# Altium schematic sheet 51电子秤.SchDoc
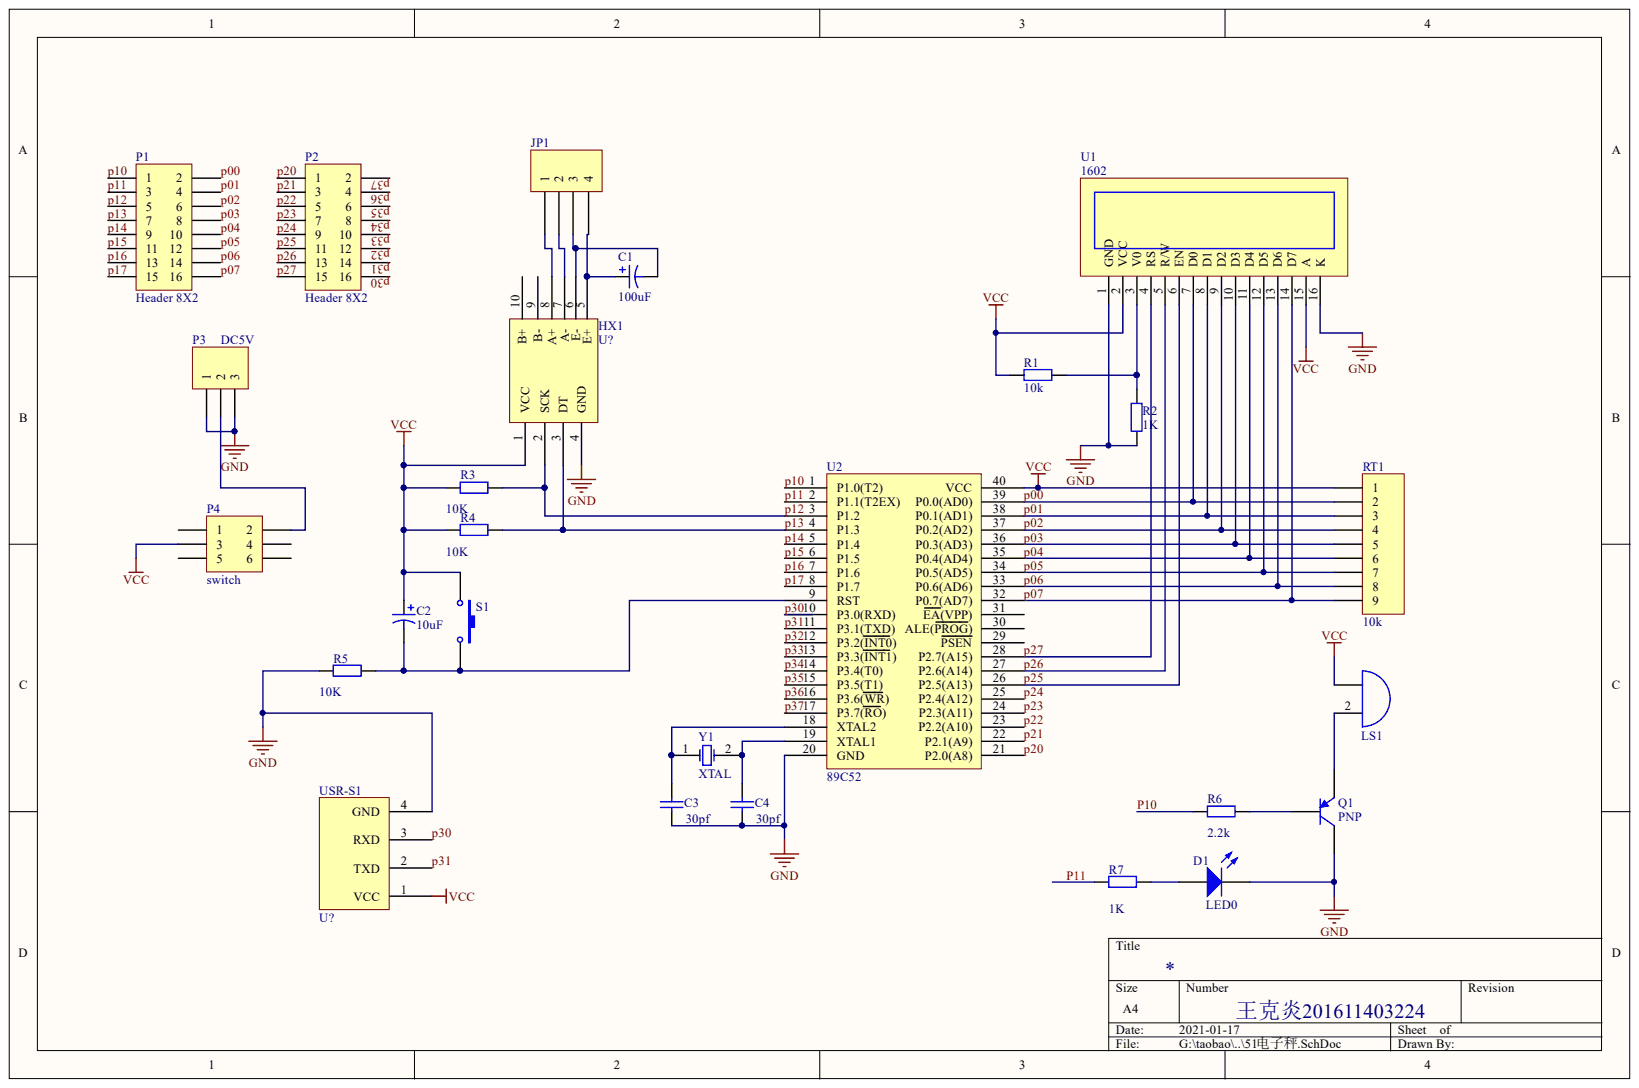
[diagram p0: 51电子秤.SchDoc]

## Parts
C1  value 100uF  (hidden comment: Cap Pol2)
C2  value 10uF  (hidden comment: Cap Pol2)
C3  value 30pf  (hidden comment: Cap)
C4  value 30pf  (hidden comment: Cap)
D1  LED0
HX1  U?
JP1  JP?
LS1  Bell  (value not drawn)
P1  Header 8X2
P2  Header 8X2
P3  DC5V
P4  switch
Q1  PNP
R1  value 10k  (hidden comment: Res2)
R2  value 1K  (hidden comment: Res2)
R3  value 10K  (hidden comment: Res2)
R4  value 10K  (hidden comment: Res2)
R5  value 10K  (hidden comment: Res2)
R6  value 2.2k  (hidden comment: Res2)
R7  value 1K  (hidden comment: Res2)
RT1  10k
S1  SW-PB  (value not drawn)
U1  1602
U2  89C52
USR-S1  U?
Y1  XTAL

## Nets
GND: C3.1 C4.1 D1.2 HX1.4(GND) P3.1 P3.3 Q1.1 R2.1 R5.1 U1.1(GND) U1.16(K) U2.20(GND) USR-S1.4(GND)
VCC: C2.1 HX1.1(VCC) LS1.1 P4.3 R1.2 R3.1 R4.1 RT1.1 S1.1 U1.2(VCC) U1.15(A) U2.40(VCC) USR-S1.1(VCC)
P00: P1.2 RT1.2 U1.7(D0) U2.39(P0.0(AD0))
P01: P1.4 RT1.3 U1.8(D1) U2.38(P0.1(AD1))
P02: P1.6 RT1.4 U1.9(D2) U2.37(P0.2(AD2))
P03: P1.8 RT1.5 U1.10(D3) U2.36(P0.3(AD3))
P04: P1.10 RT1.6 U1.11(D4) U2.35(P0.4(AD4))
P05: P1.12 RT1.7 U1.12(D5) U2.34(P0.5(AD5))
P06: P1.14 RT1.8 U1.13(D6) U2.33(P0.6(AD6))
P07: P1.16 RT1.9 U1.14(D7) U2.32(P0.7(AD7))
P10: P1.1 R6.1 U2.1(P1.0(T2))
P11: P1.3 R7.1 U2.2(P1.1(T2EX))
P12: HX1.2(SCK) P1.5 R3.2 U2.3(P1.2)
P13: HX1.3(DT) P1.7 R4.2 U2.4(P1.3)
P14: P1.9 U2.5(P1.4)
P15: P1.11 U2.6(P1.5)
P16: P1.13 U2.7(P1.6)
P17: P1.15 U2.8(P1.7)
P20: P2.1 U2.21(P2.0(A8))
P21: P2.3 U2.22(P2.1(A9))
P22: P2.5 U2.23(P2.2(A10))
P23: P2.7 U2.24(P2.3(A11))
P24: P2.9 U2.25(P2.4(A12))
P25: P2.11 U1.6(EN) U2.26(P2.5(A13))
P26: P2.13 U1.5(R/W) U2.27(P2.6(A14))
P27: P2.15 U1.4(RS) U2.28(P2.7(A15))
P30: P2.16 U2.10(P3.0(RXD)) USR-S1.3(RXD)
P31: P2.14 U2.11(P3.1(TXD)) USR-S1.2(TXD)
P32: P2.12 U2.12(P3.2(INT0))
P33: P2.10 U2.13(P3.3(INT1))
P34: P2.8 U2.14(P3.4(T0))
P35: P2.6 U2.15(P3.5(T1))
P36: P2.4 U2.16(P3.6(WR))
P37: P2.2 U2.17(P3.7(RO))
NetC1_1: C1.1 HX1.5(E+) JP1.4
NetC1_2: C1.2 HX1.6(E-) JP1.3
NetC2_2: C2.2 R5.2 S1.2 U2.9(RST)
NetC3_2: C3.2 U2.18(XTAL2) Y1.1
NetC4_2: C4.2 U2.19(XTAL1) Y1.2
NetD1_1: D1.1 R7.2
NetHX1_7: HX1.7(A-) JP1.2
NetHX1_8: HX1.8(A+) JP1.1
NetLS1_2: LS1.2 Q1.3
NetP3_2: P3.2 P4.2
NetQ1_2: Q1.2 R6.2
NetR1_1: R1.1 R2.2 U1.3(V0)

Not connected: HX1 9(B-) 10(B+); P4 1 4 5 6; U2 29(PSEN) 30(ALE(PROG)) 31(EA(VPP))

## Library data of the parts
R3 = (no symbol name): Value: 10K; Published: 8-Jun-2000; LatestRevisionDate: 17-Jul-2002; LatestRevisionNote: Re-released for DXP Platform.; PackageReference: AXIAL-0.4; PackageDescription: Axial Device, Thru-Hole; 2 Leads; 0.4 in Pin Spacing; Publisher: Altium Limited
C1-C4 D1 HX1 JP1 LS1 P1-P4 Q1 R1 R2 R4-R7 RT1 S1 U1 U2 USR-S1 Y1 = (no symbol name)
  C1 C2: Published: 8-Jun-2000; LatestRevisionDate: 17-Jul-2002; LatestRevisionNote: Re-released for DXP Platform.; PackageReference: POLAR0.8; PackageDescription: Polarized Capacitor; 2 Leads
  C3 C4: Published: 8-Jun-2000; LatestRevisionDate: 17-Jul-2002; LatestRevisionNote: Re-released for DXP Platform.; PackageReference: RAD-0.3; PackageDescription: Radial Cap, Thru-Hole; 2 Leads; 0.3 in Pin Spacing
  D1: Published: 8-Jun-2000; LatestRevisionDate: 08-Jul-2005; LatestRevisionNote: Mech Layer 1 removed.; PackageReference: LED-0; PackageDescription: LED; 2 Leads
  LS1: Published: 24-Mar-1999; LatestRevisionDate: 17-Jul-2002; LatestRevisionNote: Re-released for DXP Platform.
  P1-P4: LatestRevisionDate: 17-Jul-2002; LatestRevisionNote: Re-released for DXP Platform.
  Q1: Published: 8-Jun-2000; LatestRevisionDate: 29-May-2009; LatestRevisionNote: IPC-7351 Footprint Added.; PackageVersion: Aug-1999; PackageReference: SOT-23B; PackageDescription: 3-Pin SOT-23 Package 0.95 mm Pitch, 2.4 mm Lead Span
  R1 R2 R4-R7: Published: 8-Jun-2000; LatestRevisionDate: 17-Jul-2002; LatestRevisionNote: Re-released for DXP Platform.; PackageReference: AXIAL-0.4; PackageDescription: Axial Device, Thru-Hole; 2 Leads; 0.4 in Pin Spacing
  S1: Published: 24-Mar-1999; LatestRevisionDate: 17-Jul-2002; LatestRevisionNote: Re-released for DXP Platform.; PackageReference: SPST-2; PackageDescription: Switch; 2 Leads
  Y1: Published: 8-Jun-2000; LatestRevisionDate: 17-Jul-2002; LatestRevisionNote: Re-released for DXP Platform.; PackageReference: R38; PackageDescription: Crystal, Thru-Hole; 2 Leads; Body 3.1 x 8.2 mm (Dia.xH)
  C1-C4 D1 LS1 P1-P4 Q1 R1 R2 R4-R7 S1 Y1: Publisher: Altium Limited
